# Altium schematic sheet 03_MCU.SchDoc
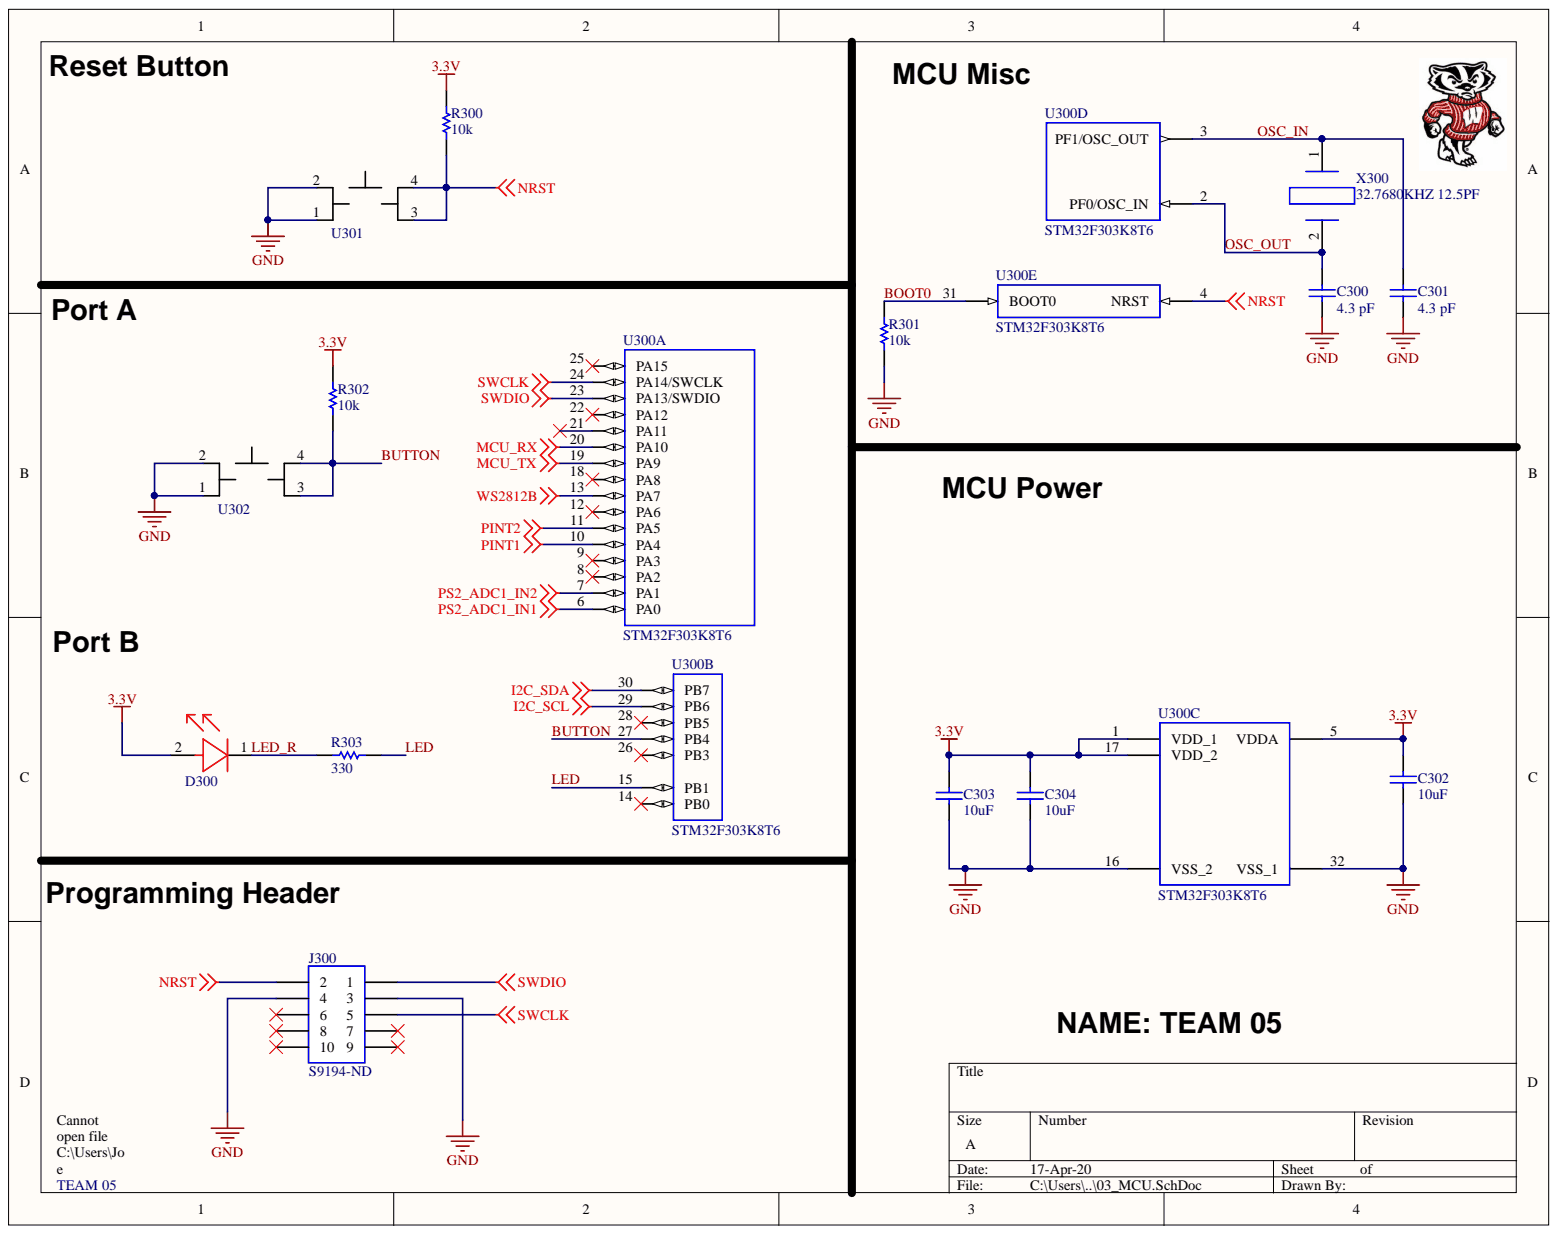
[diagram p0: 03_MCU.SchDoc]

## Parts
C300  Capacitance Value: 4.3 pF  [CAP_0805]  (hidden comment: CAP_0805_P_004.30)
C301  Capacitance Value: 4.3 pF  [CAP_0805]  (hidden comment: CAP_0805_P_004.30)
C302  10uF  [CAP_0805]
C303  10uF  [CAP_0805]
C304  10uF  [CAP_0805]
D300  LED_RED_0805.1  [LED_RED_0805]  (value not drawn)
J300  Supplier Part Number 1: S9194-ND  [SFH11-PBPC-D05-ST-BK]  (hidden comment: SFH11-PBPC-D05-ST-BK)
R300  10K  [RES_0805]
R301  10K  [RES_0805]
R302  10K  [RES_0805]
R303  330  [RES_0805]
U300  Manufacturer Part Number: STM32F303K8T6  [LQFP32]  (hidden comment: IC_STM32F303K8T6)  (parts A,B,C,D,E)
U301  SWITCH_6mm_6mm_smd  [SWITCH_6mm_6mm_smd]  (value not drawn)
U302  SWITCH_6mm_6mm_smd  [SWITCH_6mm_6mm_smd]  (value not drawn)
X300  32.7680KHZ 12.5PF  [NX3215SA-32.768K-STD-MUA-8]

## Nets
3.3V: C302.2 C303.2 C304.2 D300.2 R300.2 R302.2 U300.1(VDD_1) U300.5(VDDA) U300.17(VDD_2)
GND: C300.1 C301.1 C302.1 C303.1 C304.1 J300.3 J300.4 R301.1 U300.16(VSS_2) U300.32(VSS_1) U301.1 U301.2 U302.1 U302.2
I2C_SCL: U300.29(PB6)
I2C_SDA: U300.30(PB7)
MCU_RX: U300.20(PA10)
MCU_TX: U300.19(PA9)
NRST: J300.2 R300.1 U300.4(NRST) U301.3 U301.4
PINT1: U300.10(PA4)
PINT2: U300.11(PA5)
PS2_ADC1_IN1: U300.6(PA0)
PS2_ADC1_IN2: U300.7(PA1)
SWCLK: J300.5 U300.24(PA14/SWCLK)
SWDIO: J300.1 U300.23(PA13/SWDIO)
WS2812B: U300.13(PA7)
BOOT0: R301.2 U300.31(BOOT0)
BUTTON: R302.1 U300.27(PB4) U302.3 U302.4
LED: R303.2 U300.15(PB1)
LED_R: D300.1 R303.1
OSC_IN: C301.2 U300.3(PF1/OSC_OUT) X300.1
OSC_OUT: C300.2 U300.2(PF0/OSC_IN) X300.2

Not connected: J300 6 7 8 9 10; U300 8(PA2) 9(PA3) 12(PA6) 14(PB0) 18(PA8) 21(PA11) 22(PA12) 25(PA15) 26(PB3) 28(PB5)

## Library data of the parts
C300 C301 = CAP_0805_P_004.30: CAP CER 4.3PF 100V NP0 0805; Manufacturer: Kyocera AVX; Manufacturer Part Number: 08051A4R3CAT2A; Dielectric: C0G; Product Depth: 1.25 mm; Product Length: 2.01 mm; Rad Hard: No; Tolerance: 0.25 pF; Voltage: 100 Vdc; Category: Capacitor Ceramic Multilayer; Component Kind: Standard; ComponentLink1Description: https://datasheet.ciiva.com/204/ccog-204944.pdf; ComponentLink1URL: https://datasheet.ciiva.com/204/ccog-204944.pdf; ComponentLink2Description: https://datasheet.ciiva.com/11570/ccog-11570969.pdf; ComponentLink2URL: https://datasheet.ciiva.com/11570/ccog-11570969.pdf; Construction: Flat; Library Name: ece315-S20.SCHLIB; Mounting: Surface Mount; Operating Temperature: -55 to 125 °C; Package/Case: 0805; Packaging: Tape & Reel; Pricing 1: 1=0.24, 10=0.167, 100=0.0946, 500=0.0668, 1000=0.0584 (USD); Stock 1: 23910; Supplier 1: Digi-Key; Supplier Part Number 1: 478-10404-1-ND
C302-C304 = CAP_0805_U_010.00: CAP CER 10UF 16V X7R 0805; Manufacturer: Samsung; Manufacturer Part Number: CL21A106KPCLQNC; Dielectric: X5R; Tolerance: 10%; Voltage Rating: 10V; Capacitance: 10uF; Case/Package: 0805; Case Code (Imperial): 0805; Case Code (Metric): 2012; Component Kind: Standard; ComponentLink1Description: https://datasheet.ciiva.com/25730/cl21a106kpclqnc-25730594.pdf; ComponentLink1URL: https://datasheet.ciiva.com/25730/cl21a106kpclqnc-25730594.pdf; ComponentLink3Description: https://datasheet.ciiva.com/26920/getdatasheetpartid-355170-26920201.pdf; ComponentLink3URL: https://datasheet.ciiva.com/26920/getdatasheetpartid-355170-26920201.pdf; Contact Plating: Tin; Depth: 1.25mm; Height: 0.85mm; Length: 2mm; Library Name: ece315-S20.SCHLIB; Max Operating Temperature: 85°C; Min Operating Temperature: -55°C; Mount: Surface Mount; Package Quantity: 1; Packaging: Tape and Reel; Pricing 1: 1=0.22, 10=0.148, 100=0.0749, 500=0.05122, 1000=0.04531 (USD); Radiation Hardening: No; Stock 1: 40000; Supplier 1: Digi-Key; Supplier Part Number 1: 1276-2402-1-ND; Thickness: 0.037inch; Width: 0.049inch
D300 = LED_RED_0805.1: LED SUPER RED CLR THIN 0805 SMD; Manufacturer: Vishay / Lite-On; Manufacturer Part Number: LTST-C171KRKT; Chip Material: AlGaInP; DELETED: Compliant; Dominant Wave Length: 631 nm; Forward Current: 30 mA; Forward Voltage: 2.4 V; High Brightness: Yes; Luminous Intensity: 54mcd; Main Category: Chip LED; Number of  Elements: 1; Peak Wavelength: 639 nm; Power Dissipation: 75 W; Product Depth (mm): 1.25 mm; Product Height (mm): 0.8 mm; Product Length (mm): 2 mm; Rad Hardened: No; Reverse Voltage: 5 V; Shape Type: Rectangular; Test Current (It): 20 mA; Type: Uni-Color; Viewing Angle: 130 deg; Component Kind: Standard; ComponentLink1Description: https://datasheet.ciiva.com/2110/s-110-ltst-c171krkt-2110066.pdf; ComponentLink1URL: https://datasheet.ciiva.com/2110/s-110-ltst-c171krkt-2110066.pdf; ComponentLink2Description: https://datasheet.ciiva.com/2110/s-110-ltst-c171krkt-2110067.pdf; ComponentLink2URL: https://datasheet.ciiva.com/2110/s-110-ltst-c171krkt-2110067.pdf; ComponentLink3Description: https://datasheet.ciiva.com/2110/s-110-ltst-c171krkt-2110068.pdf; ComponentLink3URL: https://datasheet.ciiva.com/2110/s-110-ltst-c171krkt-2110068.pdf; ComponentLink4Description: https://datasheet.ciiva.com/12403/lite-on-ltst-c171krkt-187201-12403781.pdf; ComponentLink4URL: https://datasheet.ciiva.com/12403/lite-on-ltst-c171krkt-187201-12403781.pdf; ComponentLink5Description: https://datasheet.ciiva.com/11775/0900766b80dc0299-11775643.pdf; ComponentLink5URL: https://datasheet.ciiva.com/11775/0900766b80dc0299-11775643.pdf; ComponentLink6Description: https://datasheet.ciiva.com/16444/lite-on20ltst-c171krkt-16444779.pdf; ComponentLink6URL: https://datasheet.ciiva.com/16444/lite-on20ltst-c171krkt-16444779.pdf; Emitting Color: Red; Lens Dimensions: 1.4x1.25x0.5 mm; Library Name: ece315-S20.SCHLIB; Lum Intensity: 54mcd; Mounting: Surface Mount; Operating Temperature Classification: Industrial; Operating Temp Range: -55C to 85C; Package Type: Chip Led; Packaging: Tape and Reel; Pin Count: 2; Pricing 1: 1=0.31, 10=0.225, 25=0.1656, 100=0.1183, 250=0.0804, 500=0.071, 1000=0.0556 (USD); Stock 1: 97347; Supplier 1: Digikey; Supplier Part Number 1: 160-1427-1-ND
J300 = SFH11-PBPC-D05-ST-BK: CONN HDR 10POS 0.1 GOLD PCB; Manufacturer 1: Sullins; Connector Type: Header; Number of Positions: 10; Pitch: 0.1inch; Color: Black; Component Kind: Standard; ComponentLink1Description: https://datasheet.ciiva.com/754/sfh11-pxxc-dxx-st-xx11160-c-754555.pdf?src-supplier=Digi-Key; ComponentLink1URL: https://datasheet.ciiva.com/754/sfh11-pxxc-dxx-st-xx11160-c-754555.pdf?src-supplier=Digi-Key; Contact Plating: Gold; Lead Free: Lead Free; Library Name: ece315-S20.SCHLIB; Manufacturer Part Number 1: SFH11-PBPC-D05-ST-BK; Mount: Through Hole; Number of Rows: 2; Package Quantity: 1; Packaging: Tray; Pricing 1: 1=0.66000, 10=0.57600, 100=0.49700, 500=0.40662, 1000=0.36144, 5000=0.32630 (USD); RoHS: Yes; Row Spacing: 0.1inch; Stock 1: 26289; Supplier 1: Digi-Key; Termination: Solder
R300-R302 = RES_0805_K_010.00: RES SMD 10K OHM 1% 1/8W 0805; Manufacturer: Panasonic / Panasonic ECG; Manufacturer Part Number: ERJ-6ENF1002V; No. of Pins: 2; Power Rating: 125mW; Product Range: PANASONIC - ERJ Series; Resistor Case Style: 0805; Resistor Element Material: Thick Film; Temperature Coefficient: ± 200ppm/°C; Voltage Rating: 150V; Component Kind: Standard; ComponentLink1Description: https://datasheet.ciiva.com/11808/0900766b80f80784-11808080.pdf; ComponentLink1URL: https://datasheet.ciiva.com/11808/0900766b80f80784-11808080.pdf; ComponentLink2Description: https://datasheet.ciiva.com/16514/aoa0000ce2-16514021.pdf; ComponentLink2URL: https://datasheet.ciiva.com/16514/aoa0000ce2-16514021.pdf; ComponentLink3Description: https://datasheet.ciiva.com/27055/1988971-27055733.pdf; ComponentLink3URL: https://datasheet.ciiva.com/27055/1988971-27055733.pdf; ComponentLink4Description: https://datasheet.ciiva.com/16759/70260748-16759350.pdf; ComponentLink4URL: https://datasheet.ciiva.com/16759/70260748-16759350.pdf; ComponentLink5Description: https://datasheet.ciiva.com/16725/70260748-16725031.pdf; ComponentLink5URL: https://datasheet.ciiva.com/16725/70260748-16725031.pdf; ComponentLink6Description: https://datasheet.ciiva.com/7830/426256-7830745.pdf; ComponentLink6URL: https://datasheet.ciiva.com/7830/426256-7830745.pdf; ComponentLink7Description: https://datasheet.ciiva.com/46372/1678782-46372714.pdf; ComponentLink7URL: https://datasheet.ciiva.com/46372/1678782-46372714.pdf; ComponentLink8Description: https://datasheet.ciiva.com/46372/1678782-46372715.pdf; ComponentLink8URL: https://datasheet.ciiva.com/46372/1678782-46372715.pdf; ComponentLink9Description: https://datasheet.ciiva.com/17212/aoa0000ce2-17212108.pdf; ComponentLink9URL: https://datasheet.ciiva.com/17212/aoa0000ce2-17212108.pdf; ComponentLink10Description: https://datasheet.ciiva.com/30140/aoa0000ce2-30140236.pdf; ComponentLink10URL: https://datasheet.ciiva.com/30140/aoa0000ce2-30140236.pdf; ComponentLink11Description: https://datasheet.ciiva.com/16740/aoa0000ce2-16740669.pdf; ComponentLink11URL: https://datasheet.ciiva.com/16740/aoa0000ce2-16740669.pdf; ComponentLink12Description: https://datasheet.ciiva.com/24070/aoa0000ce2-24070702.pdf; ComponentLink12URL: https://datasheet.ciiva.com/24070/aoa0000ce2-24070702.pdf; Library Name: ece315-S20.SCHLIB; Pricing 1: 1=0.1, 10=0.041, 100=0.0167, 1000=0.0075, 2500=0.0065 (USD); Resistance: 10k; Resistance Tolerance: ± 1%; Resistor Element Type: Thick Film; Resistor Mounting: SMD; Series: ERJ; Stock 1: 87; Supplier 1: Digikey; Supplier Part Number 1: P10.0KCCT-ND
R303 = RES_0805_R_330.00: RES SMD 330 OHM 1% 1/8W 0805; Manufacturer: Panasonic / Panasonic ECG; Manufacturer Part Number: ERJ-6ENF3300V; Power Rating: 125mW; Product Range: PANASONIC - ERJ Series; Resistor Case Style: 0805 ^2012 Metric]; Resistor Element Type: Thick Film; SVHC: To Be Advised; Temperature Coefficient: ± 200ppm/°C; Voltage Rating: 150V; Component Kind: Standard; ComponentLink1Description: https://datasheet.ciiva.com/11821/0900766b80f80784-11821635.pdf; ComponentLink1URL: https://datasheet.ciiva.com/11821/0900766b80f80784-11821635.pdf; ComponentLink2Description: https://datasheet.ciiva.com/30131/aoa0000ce2-30131349.pdf; ComponentLink2URL: https://datasheet.ciiva.com/30131/aoa0000ce2-30131349.pdf; ComponentLink3Description: https://datasheet.ciiva.com/24025/aoa0000ce2-24025991.pdf; ComponentLink3URL: https://datasheet.ciiva.com/24025/aoa0000ce2-24025991.pdf; ComponentLink4Description: https://datasheet.ciiva.com/17206/aoa0000ce2-17206152.pdf; ComponentLink4URL: https://datasheet.ciiva.com/17206/aoa0000ce2-17206152.pdf; ComponentLink5Description: https://datasheet.ciiva.com/46436/1678782-46436633.pdf; ComponentLink5URL: https://datasheet.ciiva.com/46436/1678782-46436633.pdf; ComponentLink6Description: https://datasheet.ciiva.com/46436/1678782-46436634.pdf; ComponentLink6URL: https://datasheet.ciiva.com/46436/1678782-46436634.pdf; ComponentLink7Description: https://datasheet.ciiva.com/16760/70260748-16760668.pdf; ComponentLink7URL: https://datasheet.ciiva.com/16760/70260748-16760668.pdf; ComponentLink8Description: https://datasheet.ciiva.com/16780/70260748-16780764.pdf; ComponentLink8URL: https://datasheet.ciiva.com/16780/70260748-16780764.pdf; ComponentLink9Description: https://datasheet.ciiva.com/22684/1678782-22684798.pdf; ComponentLink9URL: https://datasheet.ciiva.com/22684/1678782-22684798.pdf; ComponentLink10Description: https://datasheet.ciiva.com/28590/1678782-28590783.pdf; ComponentLink10URL: https://datasheet.ciiva.com/28590/1678782-28590783.pdf; Library Name: ece315-S20.SCHLIB; Packaging: Full Reel; Pricing 1: 1=0.1, 10=0.041, 100=0.0167, 1000=0.0075, 2500=0.0065 (USD); Resistance: 330; Resistance Tolerance: ± 1%; Stock 1: 233511; Supplier 1: Digikey; Supplier Part Number 1: P330CCT-ND
U300 = IC_STM32F303K8T6: ARM® Cortex®-M4 STM32 F3 Microcontroller IC 32-Bit 72MHz 64KB (64K x 8) FLASH 32-LQFP (7x7); Manufacturer: STMicroelectronics; Connectivity: CAN, I²C, IrDA, LIN, SPI, UART/USART; Data Converters: A/D 9x12b, D/A 3x12b; Number of I/O: 10; Oscillator Type: Internal; Peripherals: DMA, I²S, POR, PWM, WDT; RAM Size: 12K x 8; Voltage - Supply (Vcc/Vdd): 2 V ~ 3.6 V; Category: Integrated Circuits (ICs); Component Kind: Standard; ComponentLink1Description: http://www.st.com/web/en/resource/technical/document/datasheet/DM00092070.pdf; ComponentLink1URL: http://www.st.com/web/en/resource/technical/document/datasheet/DM00092070.pdf; Core Processor: ARM® Cortex®-M4; Core Size: 32-Bit; EEPROM Size: -; Family: Embedded - Microcontrollers; Library Name: ece315-S20.SCHLIB; Operating Temperature: -40°C ~ 85°C (TA); Package / Case: 32-LQFP; Packaging: Tray; Pricing 1: 1=4.28, 10=3.846, 100=3.1512, 500=2.6826, 1000=2.2624 (USD); Program Memory Size: 64KB (64K x 8); Program Memory Type: FLASH; Series: STM32 F3; Speed: 72MHz; Stock 1: 848; Supplier 1: Digikey; Supplier Device Package: 32-LQFP (7x7); Supplier Part Number 1: 497-15828-ND
U301 U302 = SWITCH_6mm_6mm_smd: SWITCH TACTILE SPST-NO 0.05A 12V; Manufacturer: C&K Components; Manufacturer Part Number: PTS645SH50SMTR92 LFS; Actuator Height off PCB, from Pins: 5.00mm; Actuator Material: Polyamide (PA66), Nylon 6/6; Actuator Orientation: Top Actuated; Actuator Type: Standard; Contact Rating @ Voltage: 0.05A @ 12VDC; Illumination: Non-Illuminated; Mechanical Life: 100,000 Cycles; Operating Force: 200gf; Outline: 6.00mm x 6.00mm; Switch Function: Off-Mom; Category: Switches; Circuit: SPST-NO; Component Kind: Standard; Features: -; Illumination Type, Color: -; Illumination Voltage (Nominal): -; Ingress Protection: -; Library Name: ece315-S20.SCHLIB; Mounting Type: Surface Mount; Operating Temperature: -20°C ~ 60°C; Packaging: Tape & Reel (TR); RoHS: RoHS Compliant; Supplier: Digi-Key; Supplier 1: Digi-Key; Supplier Part Number: CKN9085TR-ND; Supplier Part Number 1: CKN9085CT-ND; Switch Travel: -; Termination Style: Gull Wing
X300 = CRYSTAL_NX3215SA-32.768K: CRYSTAL 32.768KHZ 12.5PF SMD; Manufacturer: Nihon Dempa Kogyo (NDK); Catalog Drawings: NX3215SA Series Top    NX3215SA Series Side    NX3215SA Series Bottom    NX3215SA Series Circuit; Dynamic Catalog: NX3215SA Series; ESR (Equivalent Series Resistance): 70 kOhm; Featured Product: NX3215SA Series 32.768KHz SMD Crystals; Frequency Tolerance: ±20ppm; Operating Mode: Fundamental; Other Names: 644-1156-6; Category: Crystals and Oscillators; Component Kind: Standard; ComponentLink1Description: https://datasheet.ciiva.com/857/c-nx3215sa-std-mua-9-e-857050.pdf; ComponentLink1URL: https://datasheet.ciiva.com/857/c-nx3215sa-std-mua-9-e-857050.pdf; ComponentLink2Description: https://datasheet.ciiva.com/857/c-nx3215sa-std-mua-9-e-857051.pdf; ComponentLink2URL: https://datasheet.ciiva.com/857/c-nx3215sa-std-mua-9-e-857051.pdf; ComponentLink3Description: https://datasheet.ciiva.com/857/c-nx3215sa-std-mua-9-e-857052.pdf; ComponentLink3URL: https://datasheet.ciiva.com/857/c-nx3215sa-std-mua-9-e-857052.pdf; Family: Crystals; Frequency: 32.768kHz; Height: 0.035" (0.90mm); Library Name: ece315-S20.SCHLIB; Load Capacitance: 12.5pF; Manufacturer Part Number: NX3215SA-32.768K-STD-MUA-8; Mounting Type: Surface Mount; Operating Temperature: -40°C ~ 85°C; Package / Case: 2-SMD; Packaging: Digi-Reel®; Pricing 1: 1=0.94, 10=0.835, 50=0.7804, 100=0.6897, 500=0.6534, 1000=0.5445 (USD); Series: NX3215SA; Size / Dimension: 0.126" L x 0.059" W (3.20mm x 1.50mm); Standard Package: 1; Stock 1: 45314; Supplier 1: Digikey; Supplier Part Number 1: 644-1156-1-ND; Type: kHz Crystal (Tuning Fork)
